# Altium schematic sheet CHEM_CONTROL_BOX.SchDoc
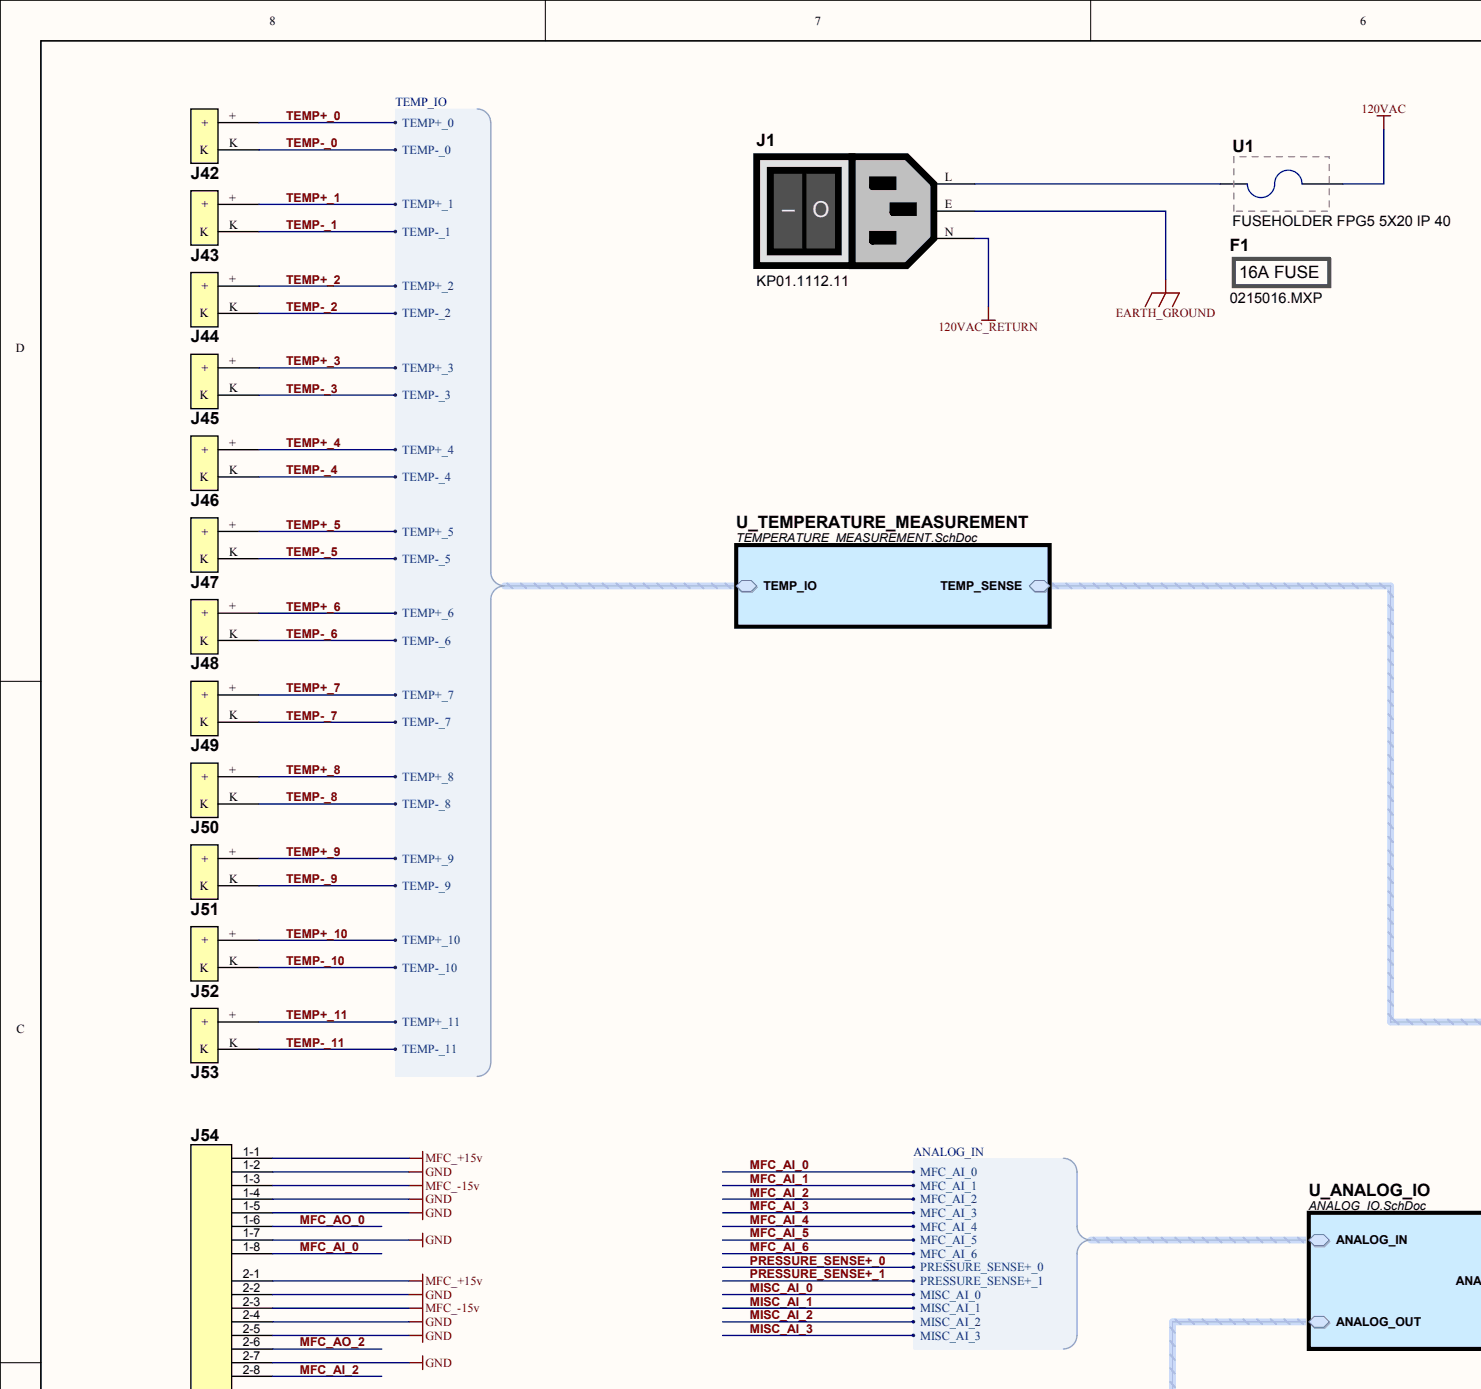
[diagram p0: CHEM_CONTROL_BOX.SchDoc, top left]
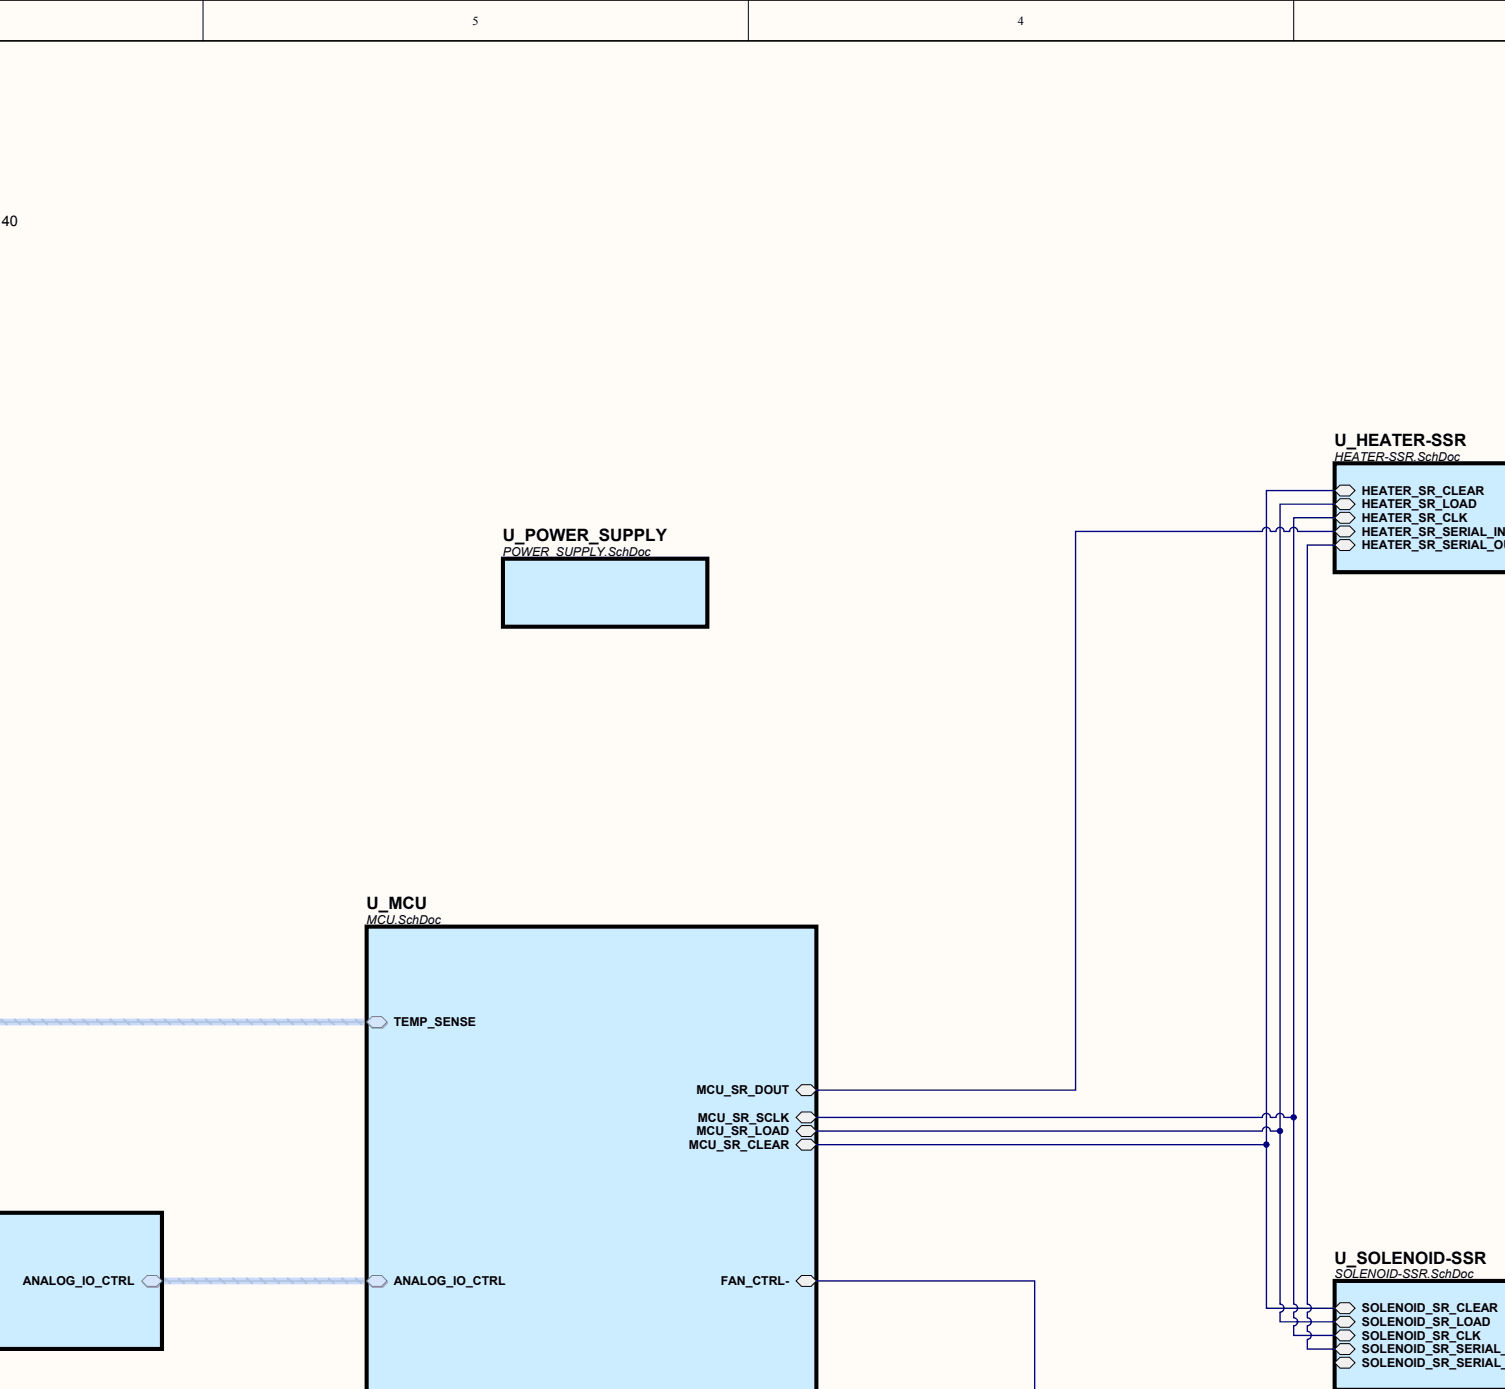
[diagram p1: CHEM_CONTROL_BOX.SchDoc, top centre]
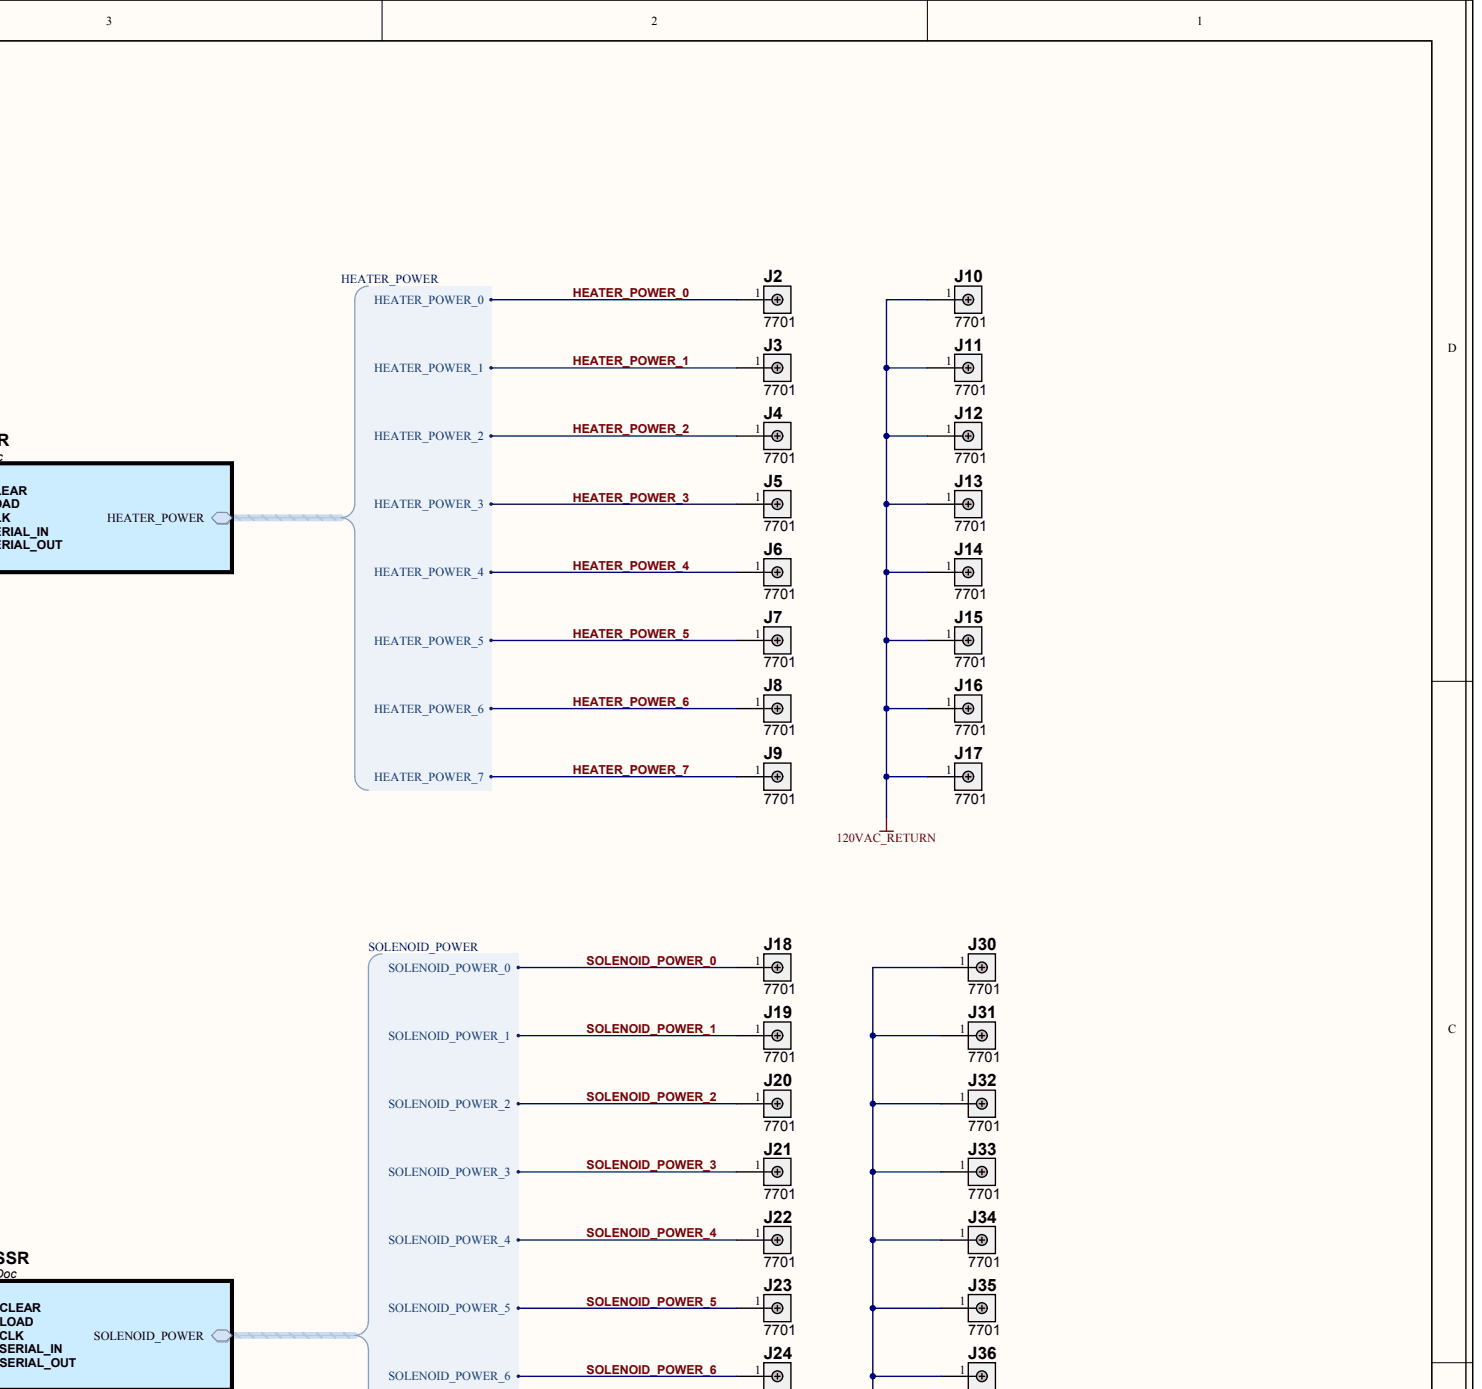
[diagram p2: CHEM_CONTROL_BOX.SchDoc, top right]
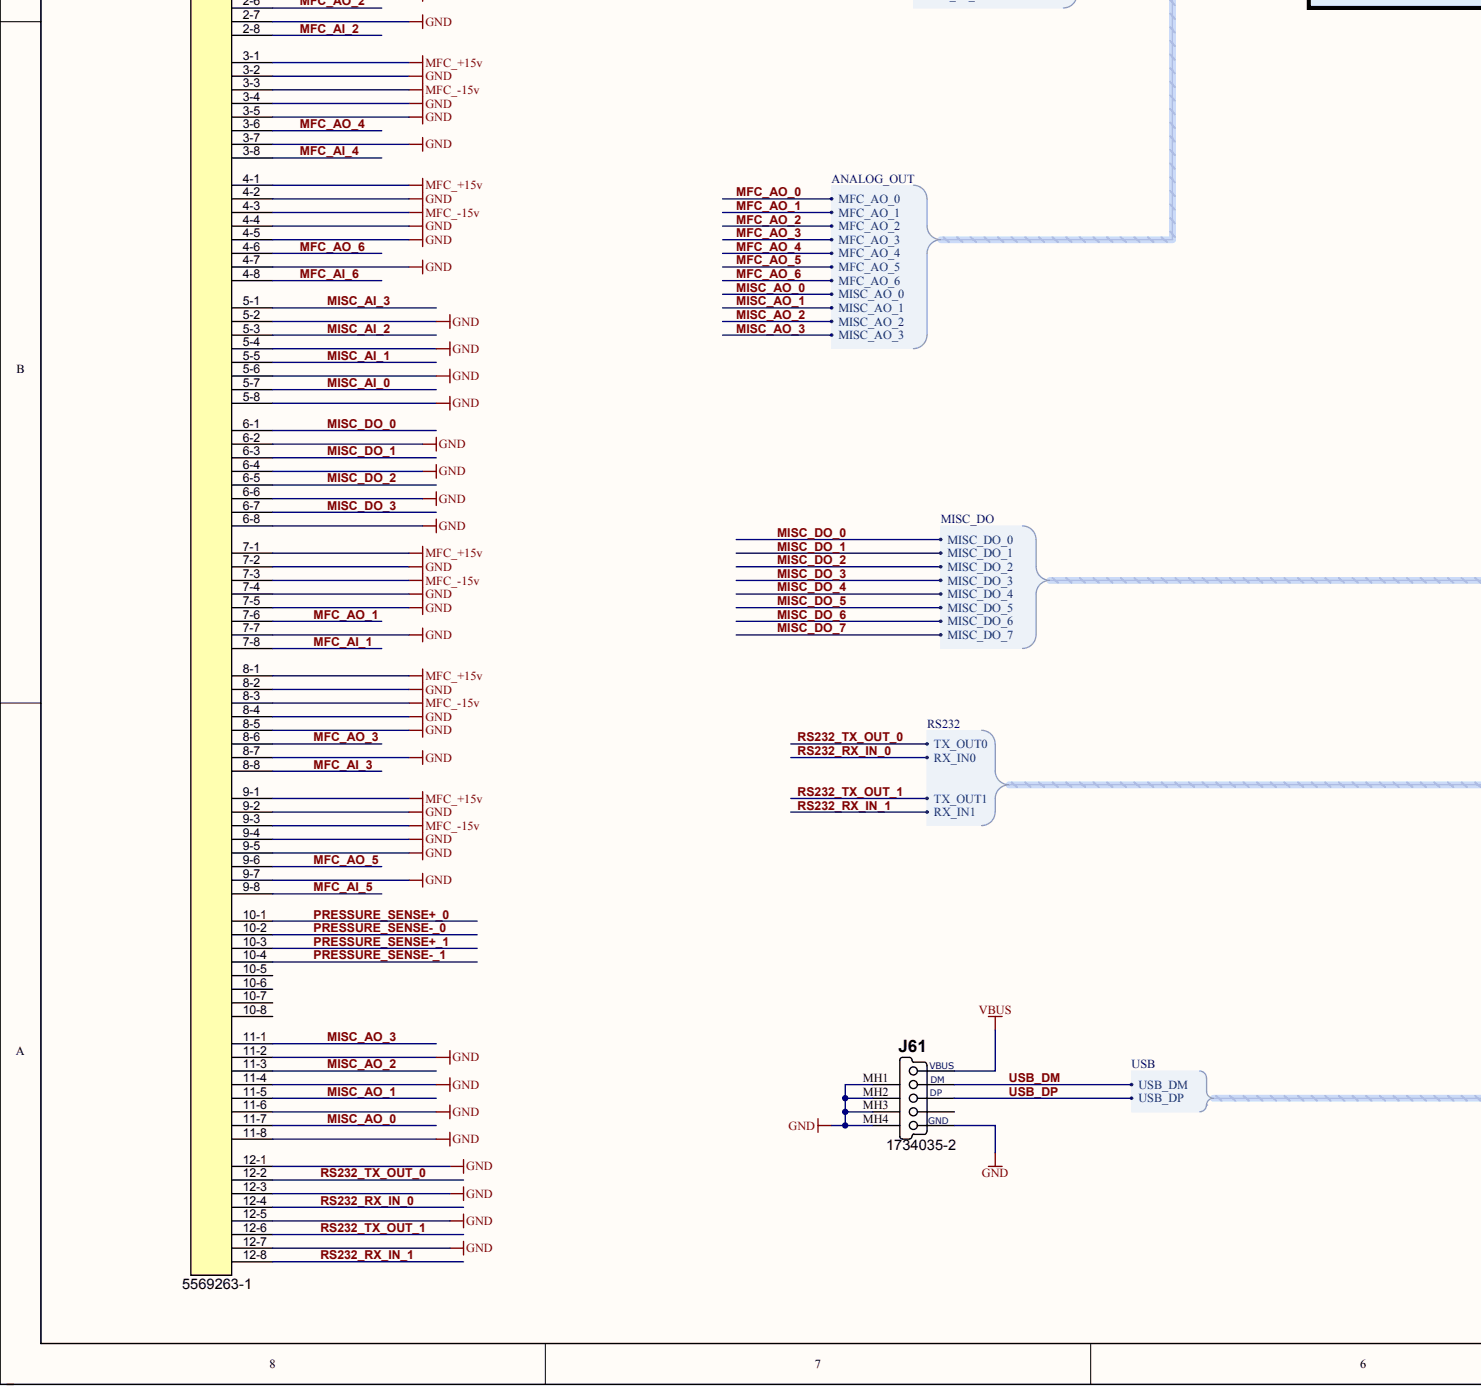
[diagram p3: CHEM_CONTROL_BOX.SchDoc, bottom left]
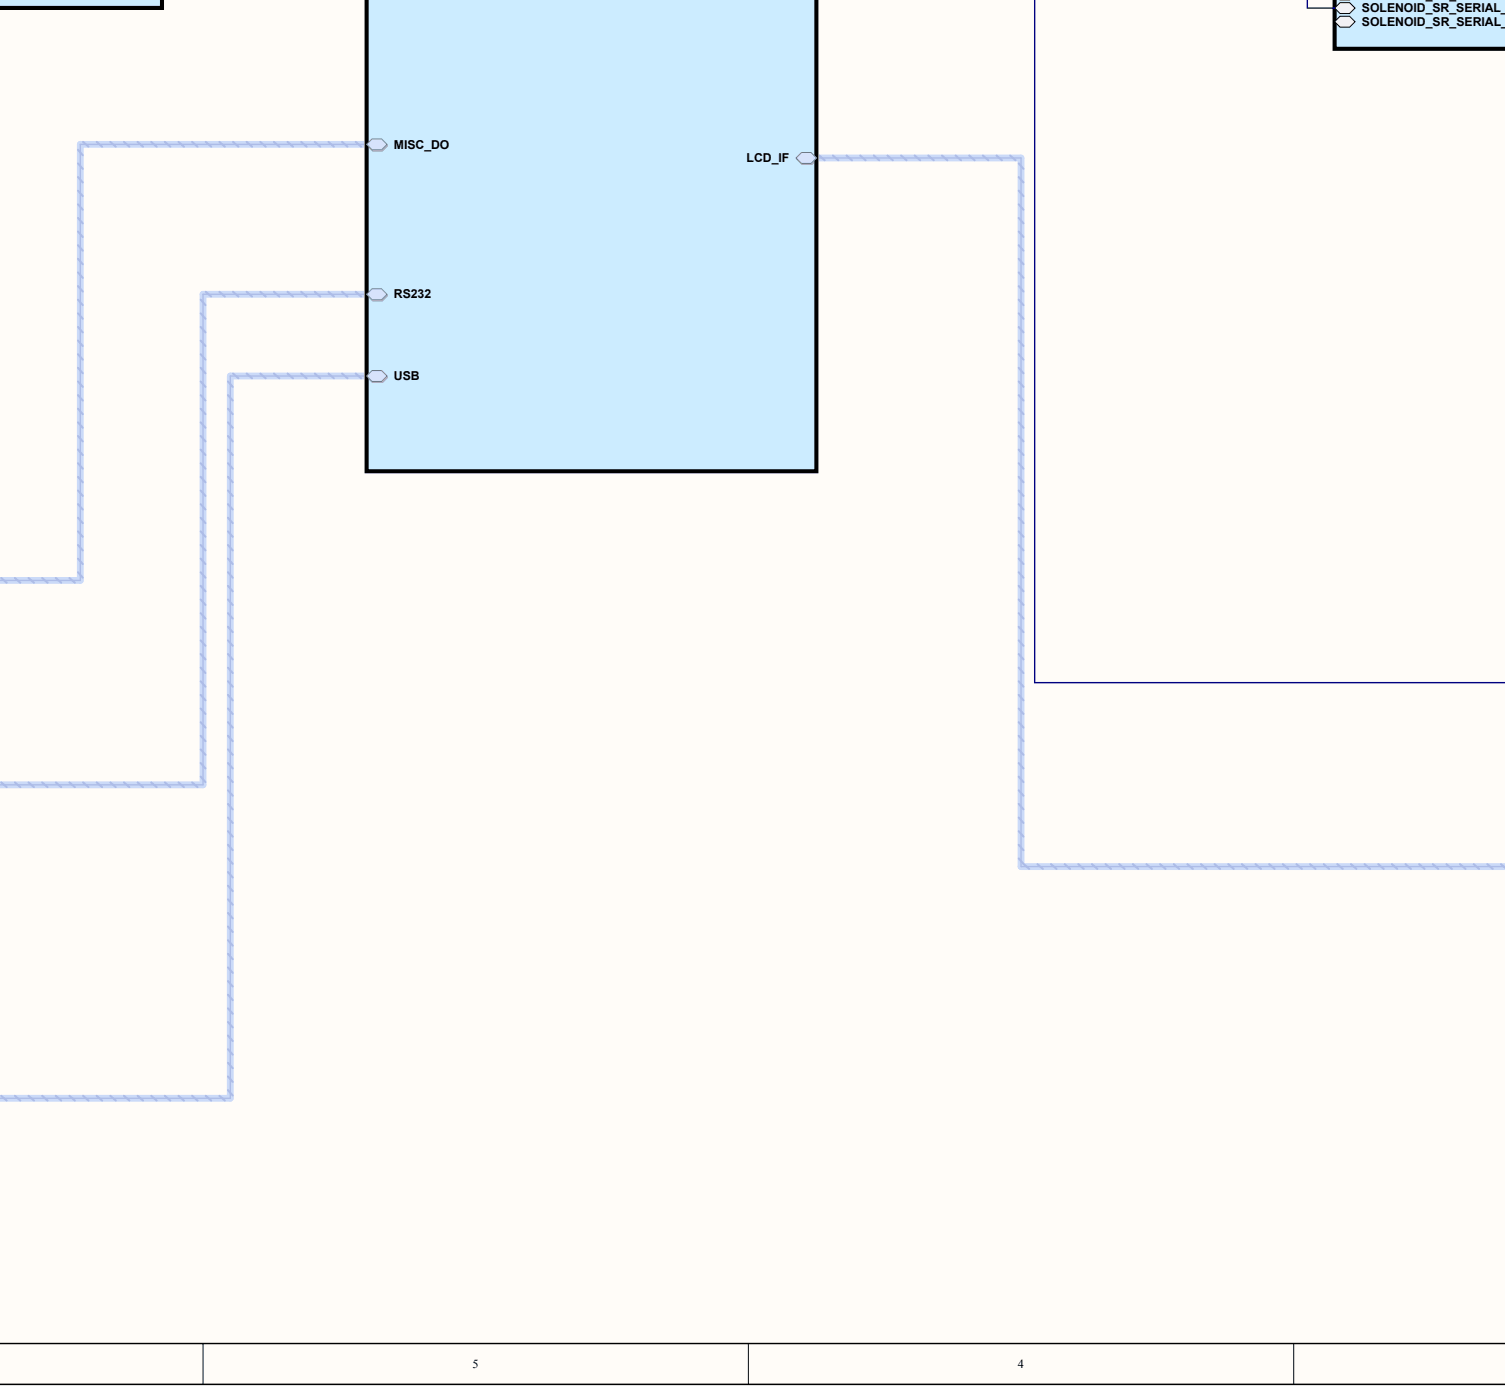
[diagram p4: CHEM_CONTROL_BOX.SchDoc, bottom centre]
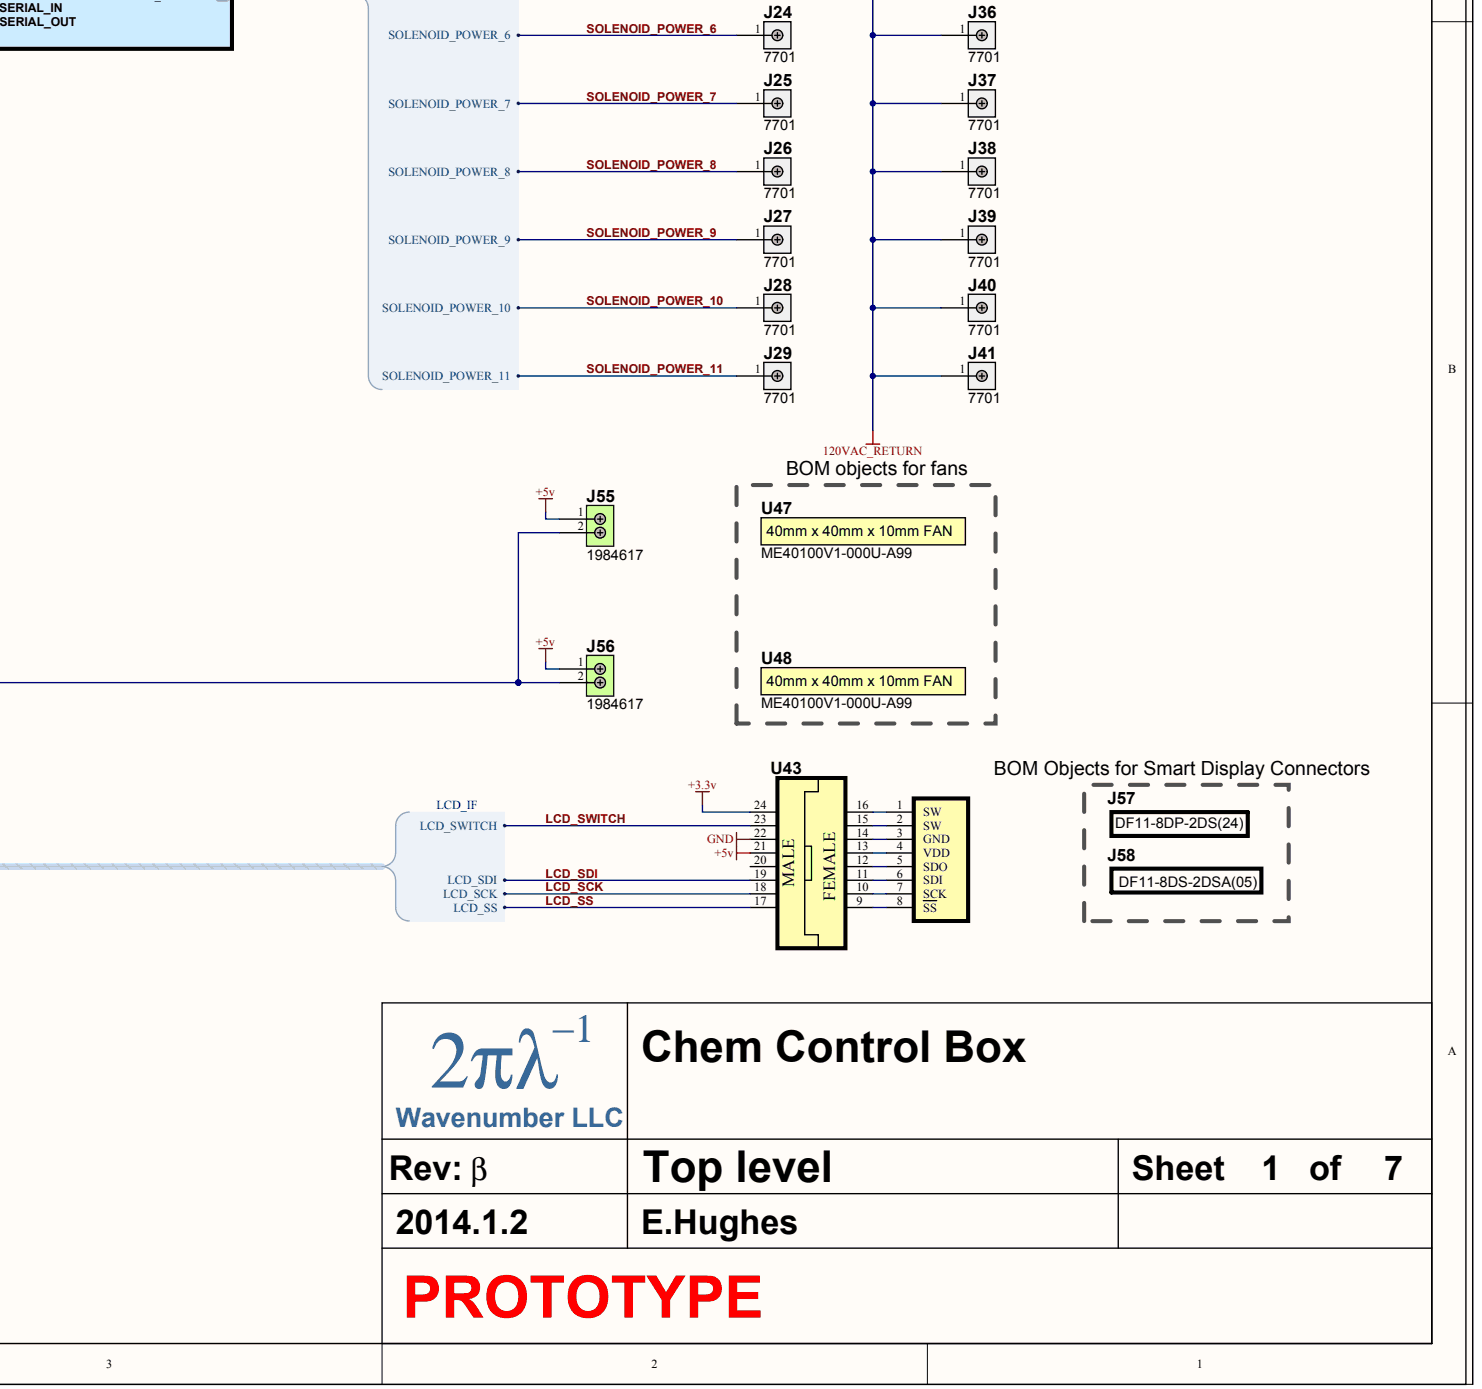
[diagram p5: CHEM_CONTROL_BOX.SchDoc, bottom right]

## Parts
F1  Current Rating: 16A  (hidden comment: 0215016.MXP)
J1  KP01.1112.11
J2  7701
J3  7701
J4  7701
J5  7701
J6  7701
J7  7701
J8  7701
J9  7701
J10  7701
J11  7701
J12  7701
J13  7701
J14  7701
J15  7701
J16  7701
J17  7701
J18  7701
J19  7701
J20  7701
J21  7701
J22  7701
J23  7701
J24  7701
J25  7701
J26  7701
J27  7701
J28  7701
J29  7701
J30  7701
J31  7701
J32  7701
J33  7701
J34  7701
J35  7701
J36  7701
J37  7701
J38  7701
J39  7701
J40  7701
J41  7701
J42  PCC-SMP-K  (value not drawn)
J43  PCC-SMP-K  (value not drawn)
J44  PCC-SMP-K  (value not drawn)
J45  PCC-SMP-K  (value not drawn)
J46  PCC-SMP-K  (value not drawn)
J47  PCC-SMP-K  (value not drawn)
J48  PCC-SMP-K  (value not drawn)
J49  PCC-SMP-K  (value not drawn)
J50  PCC-SMP-K  (value not drawn)
J51  PCC-SMP-K  (value not drawn)
J52  PCC-SMP-K  (value not drawn)
J53  PCC-SMP-K  (value not drawn)
J54  5569263-1
J55  1984617
J56  1984617
J57  DF11-8DP-2DS(24)
J58  DF11-8DS-2DSA(05)
J61  1734035-2
U1  FUSEHOLDER FPG5 5X20 IP 40
U43  IS15DSBFP4RGB  (value not drawn)
U47  ME40100V1-000U-A99
U48  ME40100V1-000U-A99

## Nets
120VAC: U1.2
120VAC_RETURN: J1.N J10.1 J11.1 J12.1 J13.1 J14.1 J15.1 J16.1 J17.1 J30.1 J31.1 J32.1 J33.1 J34.1 J35.1 J36.1 J37.1 J38.1 J39.1 J40.1 J41.1
+3.3V: U43.24
+5V: J55.1 J56.1 U43.21
EARTH_GROUND: J1.E
GND: J54.1-2 J54.1-4 J54.1-5 J54.1-7 J54.2-2 J54.2-4 J54.2-5 J54.2-7 J54.3-2 J54.3-4 J54.3-5 J54.3-7 J54.4-2 J54.4-4 J54.4-5 J54.4-7 J54.5-2 J54.5-4 J54.5-6 J54.5-8 J54.6-2 J54.6-4 J54.6-6 J54.6-8 J54.7-2 J54.7-4 J54.7-5 J54.7-7 J54.8-2 J54.8-4 J54.8-5 J54.8-7 J54.9-2 J54.9-4 J54.9-5 J54.9-7 J54.11-2 J54.11-4 J54.11-6 J54.11-8 J54.12-1 J54.12-3 J54.12-5 J54.12-7 J61.5 J61.MH1 J61.MH2 J61.MH3 J61.MH4 U43.22
MFC_+15V: J54.1-1 J54.2-1 J54.3-1 J54.4-1 J54.7-1 J54.8-1 J54.9-1
MFC_-15V: J54.1-3 J54.2-3 J54.3-3 J54.4-3 J54.7-3 J54.8-3 J54.9-3
VBUS: J61.1
HEATER_POWER_0: J2.1
HEATER_POWER_1: J3.1
HEATER_POWER_2: J4.1
HEATER_POWER_3: J5.1
HEATER_POWER_4: J6.1
HEATER_POWER_5: J7.1
HEATER_POWER_6: J8.1
HEATER_POWER_7: J9.1
LCD_SCK: U43.18
LCD_SDI: U43.19
LCD_SS: U43.17
LCD_SWITCH: U43.23
MFC_AI_0: J54.1-8
MFC_AI_1: J54.7-8
MFC_AI_2: J54.2-8
MFC_AI_3: J54.8-8
MFC_AI_4: J54.3-8
MFC_AI_5: J54.9-8
MFC_AI_6: J54.4-8
MFC_AO_0: J54.1-6
MFC_AO_1: J54.7-6
MFC_AO_2: J54.2-6
MFC_AO_3: J54.8-6
MFC_AO_4: J54.3-6
MFC_AO_5: J54.9-6
MFC_AO_6: J54.4-6
MISC_AI_0: J54.5-7
MISC_AI_1: J54.5-5
MISC_AI_2: J54.5-3
MISC_AI_3: J54.5-1
MISC_AO_0: J54.11-7
MISC_AO_1: J54.11-5
MISC_AO_2: J54.11-3
MISC_AO_3: J54.11-1
MISC_DO_0: J54.6-1
MISC_DO_1: J54.6-3
MISC_DO_2: J54.6-5
MISC_DO_3: J54.6-7
PRESSURE_SENSE+_0: J54.10-1
PRESSURE_SENSE+_1: J54.10-3
PRESSURE_SENSE-_0: J54.10-2
PRESSURE_SENSE-_1: J54.10-4
RS232_RX_IN_0: J54.12-4
RS232_RX_IN_1: J54.12-8
RS232_TX_OUT_0: J54.12-2
RS232_TX_OUT_1: J54.12-6
SOLENOID_POWER_0: J18.1
SOLENOID_POWER_1: J19.1
SOLENOID_POWER_2: J20.1
SOLENOID_POWER_3: J21.1
SOLENOID_POWER_4: J22.1
SOLENOID_POWER_5: J23.1
SOLENOID_POWER_6: J24.1
SOLENOID_POWER_7: J25.1
SOLENOID_POWER_8: J26.1
SOLENOID_POWER_9: J27.1
SOLENOID_POWER_10: J28.1
SOLENOID_POWER_11: J29.1
TEMP+_0: J42.+
TEMP+_1: J43.+
TEMP+_2: J44.+
TEMP+_3: J45.+
TEMP+_4: J46.+
TEMP+_5: J47.+
TEMP+_6: J48.+
TEMP+_7: J49.+
TEMP+_8: J50.+
TEMP+_9: J51.+
TEMP+_10: J52.+
TEMP+_11: J53.+
TEMP-_0: J42.K
TEMP-_1: J43.K
TEMP-_2: J44.K
TEMP-_3: J45.K
TEMP-_4: J46.K
TEMP-_5: J47.K
TEMP-_6: J48.K
TEMP-_7: J49.K
TEMP-_8: J50.K
TEMP-_9: J51.K
TEMP-_10: J52.K
TEMP-_11: J53.K
USB_DM: J61.2
USB_DP: J61.3
NetJ1_L: J1.L U1.1
NetJ54_10-5: J54.10-5
NetJ54_10-6: J54.10-6
NetJ54_10-7: J54.10-7
NetJ54_10-8: J54.10-8
NetJ55_2: J55.2 J56.2
NetJ61_4: J61.4
NetU43_1: U43.1 U43.16
NetU43_2: U43.2 U43.15
NetU43_3: U43.3 U43.14
NetU43_4: U43.4 U43.13
NetU43_5: U43.5 U43.12
NetU43_6: U43.6 U43.11
NetU43_7: U43.7 U43.10
NetU43_8: U43.8 U43.9
NetU43_20: U43.20
ANALOG_IO_CTRL.AIO_ADC1_CS:
ANALOG_IO_CTRL.AIO_ADC2_CS:
ANALOG_IO_CTRL.AIO_CLK:
ANALOG_IO_CTRL.AIO_DAC1_CS:
ANALOG_IO_CTRL.AIO_DAC2_CS:
ANALOG_IO_CTRL.AIO_DIN:
ANALOG_IO_CTRL.AIO_DOUT:
HEATER_SR_CLEAR:
HEATER_SR_CLK:
HEATER_SR_LOAD:
HEATER_SR_SERIAL_IN:
HEATER_SR_SERIAL_OUT:
MISC_DO_4:
MISC_DO_5:
MISC_DO_6:
MISC_DO_7:
SOLENOID_SR_SERIAL_OUT:
TEMP_SENSE.TEMP_SENSE_A0:
TEMP_SENSE.TEMP_SENSE_A1:
TEMP_SENSE.TEMP_SENSE_A2:
TEMP_SENSE.TEMP_SENSE_A3:
TEMP_SENSE.TEMP_SENSE_CS:
TEMP_SENSE.TEMP_SENSE_SCK:
TEMP_SENSE.TEMP_SENSE_SO:

## Library data of the parts
F1 = (no symbol name): Manufacturer: Littelfuse Inc; Fuse Type: Cartridge, Ceramic; Voltage Rating - AC: 250V; Response Time: Slow; Breaking Capacity @ Rated Voltage: 500A; Melting I²t: 1408; Approvals: PSE, SEMKO, UL; DC Cold Resistance: 0.0031 Ohm; Value: 0215016.MXP; Supplier 1: Digi-Key; Supplier Part Number 1: F6044-ND; Manufacturer Part Number: 0215016.MXP; RoHS: RoHS Compliant; Category: Circuit Protection; Stock 1: 800; Pricing 1: 1=1.61, 10=1.456, 25=1.3796, 50=1.1754, 100=0.9965, 250=0.97092, 500=0.8176, 1000=0.72818, 2500=0.68985 (USD); Packaging: Bulk; Voltage Rating - DC: -; Package / Case: 5mm x 20mm; Mounting Type: Holder; Operating Temperature: -55°C ~ 125°C; Color: -; Size / Dimension: 0.205" Dia x 0.787" L (5.20mm x 20.00mm); ComponentLink1URL: http://www.littelfuse.com/data/en/Data_Sheets/Littelfuse_Fuse_215_Series.pdf; ComponentLink1Description: http://www.littelfuse.com/data/en/Data_Sheets/Littelfuse_Fuse_215_Series.pdf
J1 = (no symbol name): Manufacturer: Schurter; Connector Type: IEC-320 C-14; Current Rating: 10 A; Filtered: No; Switch Function: Switched; Voltage Rating: 250 V; Value: KP01.1112.11; Supplier 1: Mouser; Supplier Part Number 1: 693-KP01.1112.11; Manufacturer Part Number: KP01.1112.11; RoHS: RoHS Compliant; Category: AC Power Entry Modules; Stock 1: 316; Pricing 1: 1=15.82, 25=14.04, 50=12.65, 100=11.93, 200=10.48 (AUD); Fused: No; Housing Material: Thermoplastic; Operating Temperature Range: - 25 C to + 70 C; Product: Inlets; Series: KP; Termination Style: Quick Connect; Flammability Rating: UL 94 V-0; Mounting Style: Snap In; Product Category: Power Entry Modules; Standard Pack Qty: 50; RoHS - Mouser: Y; Minimum Order Quantity: 1; Reeling: False; ComponentLink1URL: http://www.schurterinc.com/pdf/english/typ_kp__switch_.pdf; ComponentLink1Description: http://www.schurterinc.com/pdf/english/typ_kp__switch_.pdf
J2-J41 = (no symbol name): Manufacturer: Keystone Electronics; Screw Size: 6-32; Current Rating: 15A; Number of Legs: 4; Screw Material: Brass; Screw Finish: Nickel; Supplier 1: Digi-Key; Supplier Part Number 1: 7701K-ND; Manufacturer Part Number: 7701; RoHS: RoHS Compliant; Category: Connectors, Interconnects; Stock 1: 77817; Pricing 1: 1=0.49, 10=0.462, 50=0.449, 100=0.354, 250=0.32324, 500=0.30784, 1000=0.256, 2500=0.23088, 5000=0.22318 (USD); Terminal Type: Screw Terminal, Power Tap; Termination: Solder; Mounting Type: Through Hole; Features: -; Contact Finish: Tin; Contact Material: Brass; Material - Insulation: -; ComponentLink1URL: http://www.keyelco.com/product-pdf.cfm?p=1081; ComponentLink1Description: http://www.keyelco.com/product-pdf.cfm?p=1081; Value: 7701
J42-J53 = (no symbol name): Manufacturer: Omega; Manufacturer Part Number]: PCC-SMP-K-100; Supplier 1: Omega; Value: PCC-SMP-K; Supplier Part Number 1: PCC-SMP-K-100
J54 = (no symbol name): Manufacturer: TE Connectivity; Connector Type: Jack; Number of Positions/Contacts: 8p8c (RJ45, Ethernet); Number of Ports: 12; Shielding: Shielded, EMI Finger; Ratings: Cat5; LED Color: Does Not Contain LED; Tab Direction: Up and Down; Value: 5569263-1; Supplier 1: Digi-Key; Supplier Part Number 1: A31480-ND; Manufacturer Part Number: 5569263-1; RoHS: RoHS Compliant; Category: Connectors, Interconnects; Stock 1: 503; Pricing 1: 1=21.11, 10=19.055, 50=17.115, 100=16.5445, 250=15.63172, 500=15.1753, 1000=14.833 (USD); Packaging: Bulk; Number of Rows: 2; Mounting Type: Through Hole; Orientation: 90° Angle (Right); Termination: Solder; Features: Board Guide; Ingress Protection: -; Contact Material: Phosphor Bronze; Contact Finish: Gold; Contact Finish Thickness: 50µin (1.27µm); Housing Material: Polycyclohexylenedimethylene Terephthalate (PCT), Polyester; Operating Temperature: -40°C ~ 85°C; ComponentLink1URL: http://www.te.com/commerce/DocumentDelivery/DDEController?Action=srchrtrv&DocNm=5569263&DocType=Customer+Drawing&DocLang=English; ComponentLink1Description: http://www.te.com/commerce/DocumentDelivery/DDEController?Action=srchrtrv&DocNm=5569263&DocType=Customer+Drawing&DocLang=English
J55 J56 = (no symbol name): Manufacturer: Phoenix Contact; Number of Levels: 1; Positions Per Level: 2; Pitch: 0.138" (3.50mm); Mating Orientation: Horizontal with Board; Current: 10A; Voltage: 300V; Wire Gauge: 16-26 AWG; Wire Termination: Screw - Rising Cage Clamp; Torque - Screw: 0.22-0.25 Nm (1.9-2.2 Lb-In); Screw Thread: M2; Supplier 1: Digi-Key; Supplier Part Number 1: 277-1721-ND; Manufacturer Part Number: 1984617; RoHS: RoHS Compliant; Category: Connectors, Interconnects; Stock 1: 10915; Pricing 1: 1=0.34, 10=0.322, 50=0.308, 100=0.2996, 250=0.28, 500=0.2576, 1000=0.238 (USD); Mounting Type: Through Hole; Features: Interlocking (Side); Color: Green; Packaging: Bulk; Operating Temperature: -; Housing Material: Polyamide (PA), Nylon; Contact Material - Plating: -; Clamp Material - Plating: -; Screw Material - Plating: -; ComponentLink1URL: http://media.digikey.com/pdf/Data%20Sheets/Phoenix%20Contact%20PDFs/1984617.pdf; ComponentLink1Description: http://media.digikey.com/pdf/Data%20Sheets/Phoenix%20Contact%20PDFs/1984617.pdf; Value: 1984617
J57 = (no symbol name): Manufacturer: Hirose Electric Co Ltd; Connector Type: Header, Shrouded; Number of Positions: 8; Number of Positions Loaded: All; Pitch: 0.079" (2.00mm); Supplier 1: Digi-Key; Manufacturer Part Number: DF11-8DP-2DS(24); RoHS: RoHS Compliant; Category: Connectors, Interconnects; Stock 1: 3605; Pricing 1: 1=0.87, 10=0.612, 50=0.472, 100=0.4108, 250=0.3496, 500=0.33212, 1000=0.29716, 2500=0.23598, 5000=0.23248 (USD); Packaging: Tube; Contact Type: Male Pin; Number of Rows: 2; Row Spacing: 0.079" (2.00mm); Contact Mating Length: 0.118" (3.00mm); Mounting Type: Through Hole, Right Angle; Termination: Solder; Fastening Type: Detent Lock; Features: -; Contact Finish: Tin; Contact Finish Thickness: -; Color: Black; ComponentLink1URL: http://www.hirose.co.jp/cataloge_hp/en_DF11_20130411.pdf; ComponentLink1Description: http://www.hirose.co.jp/cataloge_hp/en_DF11_20130411.pdf; Supplier Part Number 1: H10246-ND; Value: DF11-8DP-2DS(24)
J58 = (no symbol name): Manufacturer: Hirose Electric Co Ltd; Connector Type: Receptacle; Number of Positions: 8; Number of Positions Loaded: All; Pitch: 0.079" (2.00mm); Supplier 1: Digi-Key; Manufacturer Part Number: DF11-8DS-2DSA(05); RoHS: RoHS Compliant; Category: Connectors, Interconnects; Stock 1: 2569; Pricing 1: 1=1.14, 10=0.885, 25=0.8252, 50=0.75, 100=0.675, 250=0.57, 500=0.54, 1000=0.48, 2500=0.399 (USD); Packaging: Bulk; Contact Type: Female Socket; Number of Rows: 2; Row Spacing: 0.079" (2.00mm); Mounting Type: Through Hole; Termination: Press-Fit; Fastening Type: -; Features: -; Contact Finish: Tin; Contact Finish Thickness: -; Color: Black; ComponentLink1URL: http://www.hirose.co.jp/cataloge_hp/en_DF11_20130411.pdf; ComponentLink1Description: http://www.hirose.co.jp/cataloge_hp/en_DF11_20130411.pdf; Supplier Part Number 1: H10170-ND; Value: DF11-8DS-2DSA(05)
J61 = (no symbol name): Manufacturer: TE Connectivity; Connector Type: USB - mini B; Number of Contacts: 5; Gender: Receptacle; Specifications: USB 2.0; Supplier 1: Digi-Key; Supplier Part Number 1: A31727CT-ND; Manufacturer Part Number: 1734035-2; RoHS: RoHS Compliant; Category: Connectors, Interconnects; Stock 1: 133786; Pricing 1: 1=1.51, 10=1.325, 25=1.2476, 50=1.1956, 100=1.1435, 250=1.03956 (USD); Mounting Type: Surface Mount, Right Angle, Horizontal; Features: Board Guide; Packaging: Cut Tape (CT); Ingress Protection: -; ComponentLink1URL: http://documents.tycoelectronics.com/commerce/DocumentDelivery/DDEController?Action=srchrtrv&DocNm=1734035&DocType=CD&DocLang=EN; ComponentLink1Description: http://documents.tycoelectronics.com/commerce/DocumentDelivery/DDEController?Action=srchrtrv&DocNm=1734035&DocType=CD&DocLang=EN; Value: 1734035-2
U1 = (no symbol name): Manufacturer: Schurter Inc; Manufacturer Part Number: 3101.0055; Fuse Size: 5mm x 20mm; Number of Circuits: 1; Voltage: 250V; Current Rating: 16A; Value: FUSEHOLDER FPG5 5X20 IP 40; Supplier 1: Digi-Key; Supplier Part Number 1: 486-2056-ND; RoHS: RoHS Compliant; Category: Circuit Protection; Stock 1: 125; Pricing 1: 1=3.07, 25=2.454, 50=2.2086, 100=2.0859, 250=1.84052, 500=1.63192 (USD); Type: Holder; Mounting Type: PCB, Horizontal; Termination Style: PC Pin; Material: Copper Alloy, Tin Plated; ComponentLink1URL: http://www.schurterinc.com/var/schurter/storage/ilcatalogue/files/document/datasheet/en/pdf/typ_FPG5.pdf; ComponentLink1Description: http://www.schurterinc.com/var/schurter/storage/ilcatalogue/files/document/datasheet/en/pdf/typ_FPG5.pdf
U43 = (no symbol name): Manufacturer: NKK Switches; Male Connetor: DF11-8DP-2DS(24); Supplier 1: Mouser; Supplier Part Number 1: 633-IS15DSBFP4RGB; Value: IS15DSBFP4RGB; Manufacturer Part Number: IS15DSBFP4RGB
U47 U48 = (no symbol name): Manufacturer: Sunon Fans; Voltage - Rated: 5VDC; Air Flow: 8.0 CFM (0.226m&sup3;/min); Static Pressure: 0.190 in H2O (47.3 Pa); Bearing Type: Vapo-Bearing™; Fan Type: Tubeaxial; Noise: 32 dB(A); Power (Watts): 1.08W; RPM: 7000 RPM; Current Rating: 0.215A; Material - Frame: Polybutylene Terephthalate (PBT); Value: ME40100V1-000U-A99; Supplier 1: Digi-Key; Supplier Part Number 1: 259-1575-ND; Manufacturer Part Number: ME40100V1-000U-A99; RoHS: RoHS Compliant; Category: Fans, Thermal Management; Stock 1: 4525; Pricing 1: 1=4.75, 10=4.356, 25=3.96, 50=3.564, 100=3.267, 250=3.11852, 500=2.9205, 1000=2.7225 (USD); Features: -; Size / Dimension: Square - 40mm L x 40mm H x 10mm W; Termination: 2 Wire Leads; Weight: 0.03 lb (13.61g); Operating Temperature: -; Voltage Range: -; Life Expectancy: -; Material - Blade: Polybutylene Terephthalate (PBT); ComponentLink1URL: http://www.sunon.com/uFiles/file/03_products/08-catalog%20download/Sunon%20DC%20Brushless%20Fan%20&%20Blower_(190-E).pdf; ComponentLink1Description: http://www.sunon.com/uFiles/file/03_products/08-catalog%20download/Sunon%20DC%20Brushless%20Fan%20&%20Blower_(190-E).pdf
